# Altium schematic sheet CC_microcontroleur.SchDoc
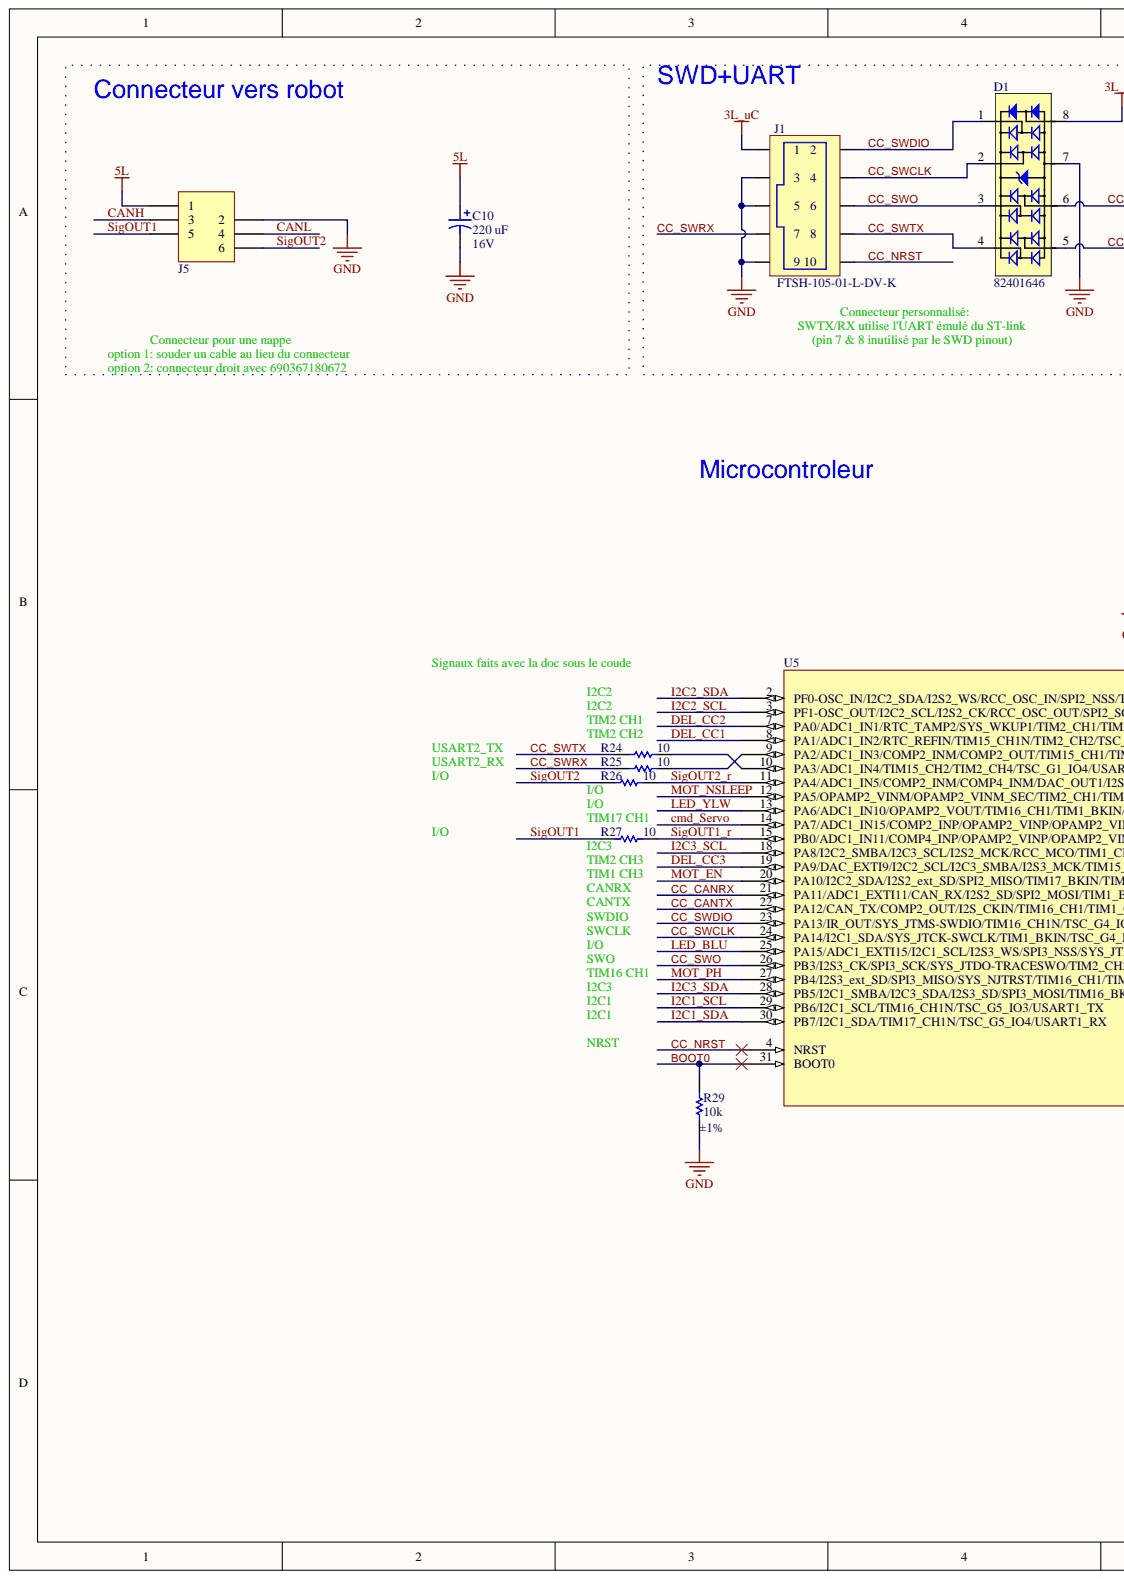
[diagram p0: CC_microcontroleur.SchDoc, left]
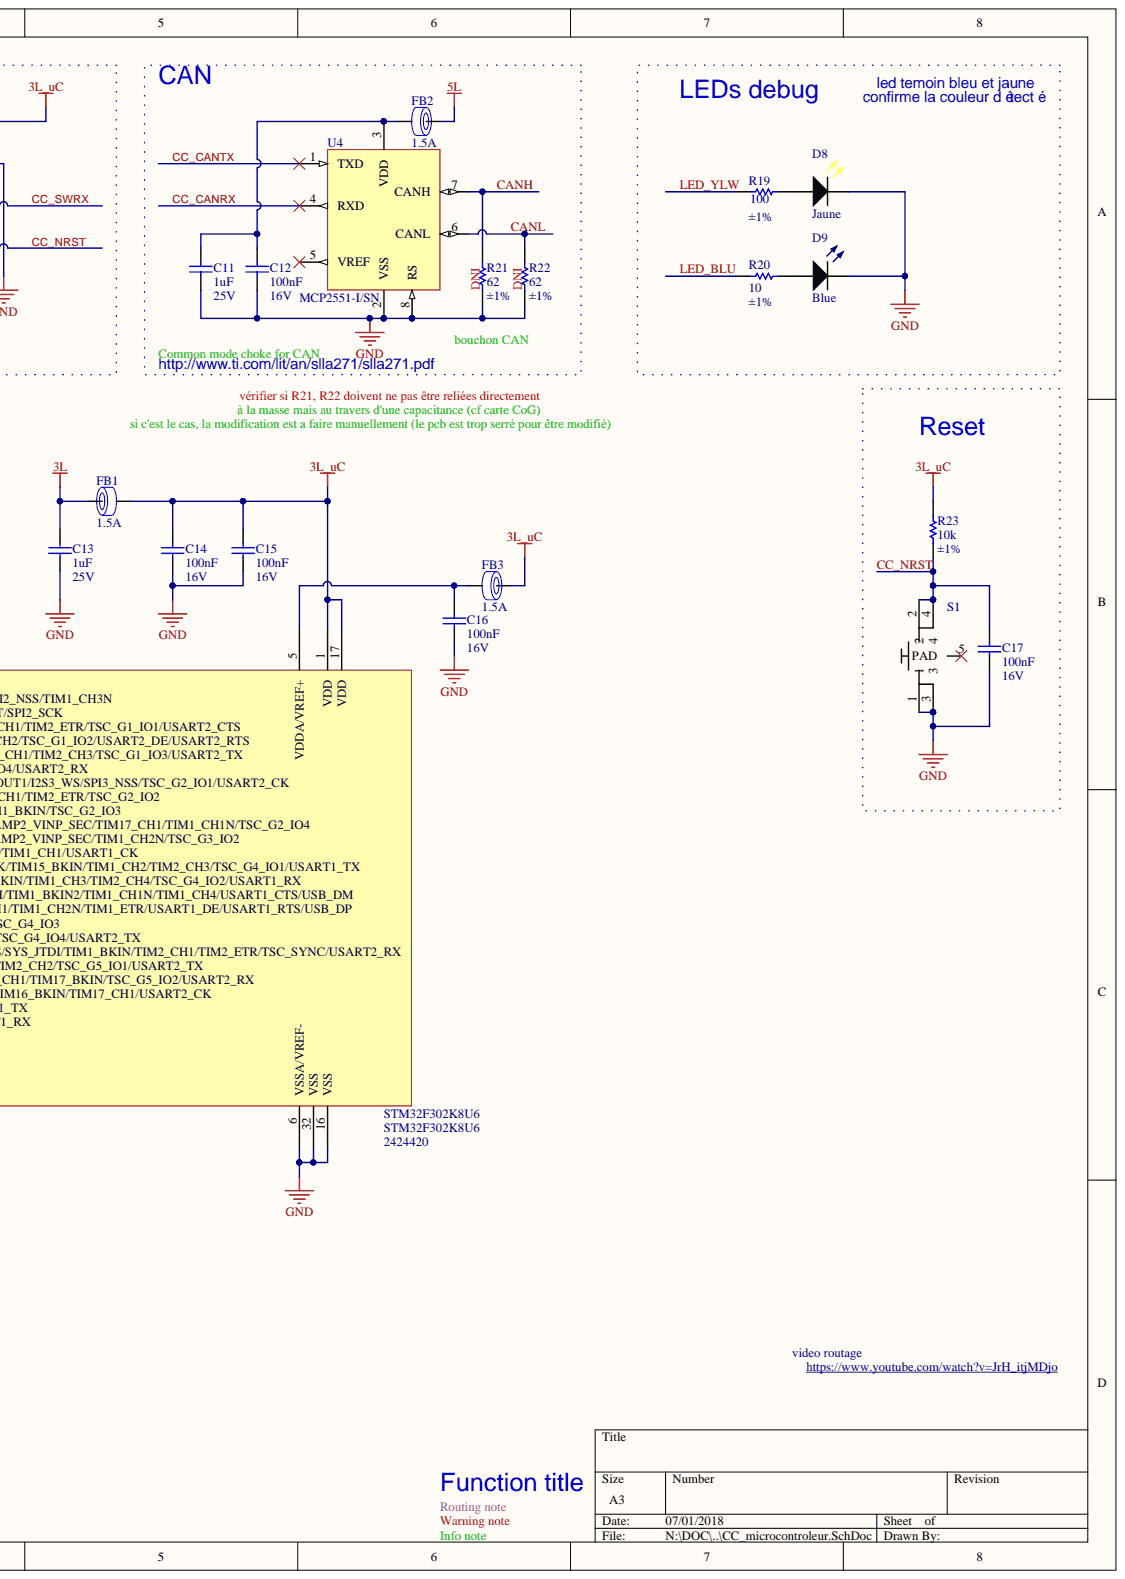
[diagram p1: CC_microcontroleur.SchDoc, right]

## Parts
C10  220 uF  RatedVoltage: 16V  [WCAP-ATG8_6.3x11x2.5x0.5]
C11  1uF  RatedVoltage: 25V  [WCAP-CSGP_0603, 1.6x0.8x0.8]
C12  100nF  RatedVoltage: 16V  [WCAP-CSGP_0402, 1x0.5x0.5]
C13  1uF  RatedVoltage: 25V  [WCAP-CSGP_0603, 1.6x0.8x0.8]
C14  100nF  RatedVoltage: 16V  [WCAP-CSGP_0402, 1x0.5x0.5]
C15  100nF  RatedVoltage: 16V  [WCAP-CSGP_0402, 1x0.5x0.5]
C16  100nF  RatedVoltage: 16V  [WCAP-CSGP_0402, 1x0.5x0.5]
C17  100nF  RatedVoltage: 16V  [WCAP-CSGP_0402, 1x0.5x0.5]
D1  82401646  [MSOP-8L]
D8  Jaune  [0603]
D9  Blue  [0603]
FB1  RatedCurrent: 1.5A  [WE-CBF_0603_High Current]  (hidden comment: 742792624)
FB2  RatedCurrent: 1.5A  [WE-CBF_0603_High Current]  (hidden comment: 742792624)
FB3  RatedCurrent: 1.5A  [WE-CBF_0603_High Current]  (hidden comment: 742792624)
J1  FTSH-105-01-L-DV-K  [FTSH-105-01-L-DV-K]
J5  value 6  [690368170672]  (hidden comment: 690368170672)
R19  value 100  Precision: ±1%  [RESC1005X04N]  (hidden comment: RC0402FR-07100RL)
R20  value 10  Precision: ±1%  [RESC1005X04N]  (hidden comment: RC0402FR-0710RL)
R21  value 62  Precision: ±1%  [RESC1005X04N]  (hidden comment: RC0402FR-0762RL)
R22  value 62  Precision: ±1%  [RESC1005X04N]  (hidden comment: RC0402FR-0762RL)
R23  value 10k  Precision: ±1%  [RESC1005X04N]  (hidden comment: RC0402FR-0710KL)
R24  value 10  [RESC1005X04N]  (hidden comment: RC0402FR-0710RL)
R25  value 10  [RESC1005X04N]  (hidden comment: RC0402FR-0710RL)
R26  value 10  [RESC1005X04N]  (hidden comment: RC0402FR-0710RL)
R27  value 10  [RESC1005X04N]  (hidden comment: RC0402FR-0710RL)
R29  value 10k  Precision: ±1%  [RESC1005X04N]  (hidden comment: RC0402FR-0710KL)
S1  MCITPG  [MCIPTG23K-V]  (value not drawn)
U4  MCP2551-I/SN  [SO8_N]
U5  STM32F302K8U6  Supplier Part Number 1: 2424420  [QFN50P500X500X60_HS-33N]

## Nets
3L: C13.2 FB1.1
3L_UC: C14.2 C15.2 D1.8 FB1.2 FB3.1 J1.1 R23.2 U5.1(VDD) U5.17(VDD)
5L: C10.1 FB2.1 J5.1
GND: C10.2 C11.1 C12.1 C13.1 C14.1 C15.1 C16.1 C17.1 D1.7 D8.1 D9.1 J1.3 J1.5 J1.9 J5.2 R21.1 R22.1 R29.1 S1.1 S1.3 U4.2(VSS) U4.8(RS) U5.6(VSSA/VREF-) U5.16(VSS) U5.32(VSS)
BOOT0: R29.2 U5.31(BOOT0)
CANH: J5.3 R21.2 U4.7(CANH)
CANL: J5.4 R22.2 U4.6(CANL)
CC_CANRX: U4.4(RXD) U5.21(PA11/ADC1_EXTI11/CAN_RX/I2S2_SD/SPI2_MOSI/TIM1_BKIN2/TIM1_CH1N/TIM1_CH4/USART1_CTS/USB_DM)
CC_CANTX: U4.1(TXD) U5.22(PA12/CAN_TX/COMP2_OUT/I2S_CKIN/TIM16_CH1/TIM1_CH2N/TIM1_ETR/USART1_DE/USART1_RTS/USB_DP)
CC_NRST: C17.2 D1.5 J1.10 R23.1 S1.2 S1.4 U5.4(NRST)
CC_SWCLK: D1.2 J1.4 U5.24(PA14/I2C1_SDA/SYS_JTCK-SWCLK/TIM1_BKIN/TSC_G4_IO4/USART2_TX)
CC_SWDIO: D1.1 J1.2 U5.23(PA13/IR_OUT/SYS_JTMS-SWDIO/TIM16_CH1N/TSC_G4_IO3)
CC_SWO: D1.3 J1.6 U5.26(PB3/I2S3_CK/SPI3_SCK/SYS_JTDO-TRACESWO/TIM2_CH2/TSC_G5_IO1/USART2_TX)
CC_SWRX: D1.6 J1.7 R25.1
CC_SWTX: D1.4 J1.8 R24.1
CMD_SERVO: U5.14(PA7/ADC1_IN15/COMP2_INP/OPAMP2_VINP/OPAMP2_VINP_SEC/TIM17_CH1/TIM1_CH1N/TSC_G2_IO4)
DEL_CC1: U5.8(PA1/ADC1_IN2/RTC_REFIN/TIM15_CH1N/TIM2_CH2/TSC_G1_IO2/USART2_DE/USART2_RTS)
DEL_CC2: U5.7(PA0/ADC1_IN1/RTC_TAMP2/SYS_WKUP1/TIM2_CH1/TIM2_ETR/TSC_G1_IO1/USART2_CTS)
DEL_CC3: U5.19(PA9/DAC_EXTI9/I2C2_SCL/I2C3_SMBA/I2S3_MCK/TIM15_BKIN/TIM1_CH2/TIM2_CH3/TSC_G4_IO1/USART1_TX)
I2C1_SCL: U5.29(PB6/I2C1_SCL/TIM16_CH1N/TSC_G5_IO3/USART1_TX)
I2C1_SDA: U5.30(PB7/I2C1_SDA/TIM17_CH1N/TSC_G5_IO4/USART1_RX)
I2C2_SCL: U5.3(PF1-OSC_OUT/I2C2_SCL/I2S2_CK/RCC_OSC_OUT/SPI2_SCK)
I2C2_SDA: U5.2(PF0-OSC_IN/I2C2_SDA/I2S2_WS/RCC_OSC_IN/SPI2_NSS/TIM1_CH3N)
I2C3_SCL: U5.18(PA8/I2C2_SMBA/I2C3_SCL/I2S2_MCK/RCC_MCO/TIM1_CH1/USART1_CK)
I2C3_SDA: U5.28(PB5/I2C1_SMBA/I2C3_SDA/I2S3_SD/SPI3_MOSI/TIM16_BKIN/TIM17_CH1/USART2_CK)
LED_BLU: R20.1 U5.25(PA15/ADC1_EXTI15/I2C1_SCL/I2S3_WS/SPI3_NSS/SYS_JTDI/TIM1_BKIN/TIM2_CH1/TIM2_ETR/TSC_SYNC/USART2_RX)
LED_YLW: R19.1 U5.13(PA6/ADC1_IN10/OPAMP2_VOUT/TIM16_CH1/TIM1_BKIN/TSC_G2_IO3)
MOT_EN: U5.20(PA10/I2C2_SDA/I2S2_ext_SD/SPI2_MISO/TIM17_BKIN/TIM1_CH3/TIM2_CH4/TSC_G4_IO2/USART1_RX)
MOT_NSLEEP: U5.12(PA5/OPAMP2_VINM/OPAMP2_VINM_SEC/TIM2_CH1/TIM2_ETR/TSC_G2_IO2)
MOT_PH: U5.27(PB4/I2S3_ext_SD/SPI3_MISO/SYS_NJTRST/TIM16_CH1/TIM17_BKIN/TSC_G5_IO2/USART2_RX)
SIGOUT1: J5.5 R27.1
SIGOUT1_R: R27.2 U5.15(PB0/ADC1_IN11/COMP4_INP/OPAMP2_VINP/OPAMP2_VINP_SEC/TIM1_CH2N/TSC_G3_IO2)
SIGOUT2: J5.6 R26.1
SIGOUT2_R: R26.2 U5.11(PA4/ADC1_IN5/COMP2_INM/COMP4_INM/DAC_OUT1/I2S3_WS/SPI3_NSS/TSC_G2_IO1/USART2_CK)
NetC11_2: C11.2 C12.2 FB2.2 U4.3(VDD)
NetC16_2: C16.2 FB3.2 U5.5(VDDA/VREF+)
NetD8_2: D8.2 R19.2
NetD9_2: D9.2 R20.2
NetR24_2: R24.2 U5.10(PA3/ADC1_IN4/TIM15_CH2/TIM2_CH4/TSC_G1_IO4/USART2_RX)
NetR25_2: R25.2 U5.9(PA2/ADC1_IN3/COMP2_INM/COMP2_OUT/TIM15_CH1/TIM2_CH3/TSC_G1_IO3/USART2_TX)

Not connected: S1 5(PAD); U4 5(VREF)

## Library data of the parts
C10 = WCAP-ATG8, 860010373010: CAP Alu 220 UF 20% 16 V; Manufacturer: Wurth Elektronik; Component Kind: Standard; ComponentLink1Description: Manufacturer; ComponentLink1URL: http://www.we-online.de; ComponentLink2Description: Datasheet; ComponentLink2URL: http://katalog.we-online.de/pbs/datasheet/860010373010.pdf; Manufacturer Part Number: 860010373010; PublishedDate: July 2016; Size: 6.3mmx11mm; Value: 220 uF; Library Name: Condensateur.IntLib
C11 C13 = WCAP-CSGP, 885012206076: CAP CER 1uF 25V X7R 0603; Manufacturer: Wurth Elektronik; CeramicType: X7R; Component Kind: Standard; ComponentLink1Description: Manufacturer; ComponentLink1URL: http://www.we-online.de; ComponentLink2Description: Datasheet; ComponentLink2URL: http://katalog.we-online.com/pbs/datasheet/885012206076.pdf; Manufacturer Part Number: 885012206076; PublishedDate: Aug 2016; Size: 0603; Value: 1uF; Library Name: Condensateur.IntLib
C12 C14-C17 = WCAP-CSGP, 885012205037: CAP CER 100nF 16V X7R 0402; Manufacturer: Wurth Elektronik; CeramicType: X7R; Component Kind: Standard; ComponentLink1Description: Manufacturer; ComponentLink1URL: http://www.we-online.de; ComponentLink2Description: Datasheet; ComponentLink2URL: http://katalog.we-online.com/pbs/datasheet/885012205037.pdf; Manufacturer Part Number: 885012205037; PublishedDate: Aug 2016; Size: 0402; Value: 100nF; Library Name: Condensateur.IntLib
D1 = 82401646: TVS DIODE 5VWM 13VC 8MSOP; Manufacturer: Wurth Electronics Inc.; Component Kind: Standard; ComponentLink1Description: Datasheet; ComponentLink1URL: http://katalog.we-online.de/pbs/datasheet/82401646.pdf; Manufacturer Part Number: 82401646; Supplier 1: Farnell; Supplier Part Number 1: 1825864; Library Name: Redressement.SchLib
D8 = WL-SMCW 0603  150060YS75000: SMD mono-color Chip LED, WL-SMCW, Yellow; Manufacturer 1: Würth Elektronik eiSos GmbH; Color: Yellow; Component Kind: Standard; ComponentLink1Description: Manufacturer; ComponentLink1URL: http://www.we-online.de; ComponentLink2Description: Datasheet; ComponentLink2URL: http://katalog.we-online.com/led/datasheet/150060YS75000.pdf; Created Date: June 2013; Manufacturer Part Number: 150060YS75000; Supplier 1: Farnell; Supplier Part Number 1: 2322074; Value: Jaune; Created by: Christin Otto; Library Name: Optoélectronique.IntLib
D9 = WL-SMCW 0603  150060BS75000: SMD mono-color Chip LED, WL-SMCW, Blue; Manufacturer 1: Würth Elektronik eiSos GmbH; Color: Blue; Component Kind: Standard; ComponentLink1Description: Manufacturer; ComponentLink1URL: http://www.we-online.de; ComponentLink2Description: Datasheet; ComponentLink2URL: http://katalog.we-online.com/led/datasheet/150060BS75000.pdf; Created Date: June 2013; Manufacturer Part Number: 150060BS75000; Supplier 1: Farnell; Supplier Part Number 1: 2322069; Value: Blue; Created by: Christin Otto; Library Name: Optoélectronique.IntLib
FB1-FB3 = WE-CBF, 742792624: Ferrite Bead 180ohm 0603 max1.5A; Manufacturer: Wurth Elektronik; Type: High Current; Value: 180Ω; Component Kind: Standard; ComponentLink1Description: Manufacturer; ComponentLink1URL: http://www.we-online.de; ComponentLink2Description: Datasheet; ComponentLink2URL: http://katalog.we-online.de/pbs/datasheet/742792624.pdf; Library Name: Inductance.IntLib; Manufacturer Part Number: 742792624; PublishedDate: Aug 2016; Size: 0603
J1 = FTSH-105-01-L-DV-K: CONN HEADER 10 POS DUAL .05" SMD with Keying; Manufacturer: Samtec Inc.; Component Kind: Standard; ComponentLink1Description: Datasheet; ComponentLink1URL: http://suddendocs.samtec.com/prints/ftsh-1xx-xx-xxx-dv-xxx-xxx-mkt.pdf; Manufacturer Part Number: FTSH-105-01-L-DV-K; Supplier 1: Digi-Key; Supplier Part Number 1: SAM8799-ND; Library Name: Connecteurs.IntLib
J5 = 690368170672: WR-MM 6p Female Angled TH Connector with Polarization; Manufacturer: Wurth Electronics Inc.; Component Kind: Standard; ComponentLink1Description: Manufacturer; ComponentLink1URL: http://www.we-online.com; ComponentLink2Description: Datasheet; ComponentLink2URL: http://katalog.we-online.de/em/datasheet/69035710xx72.pdf; Manufacturer Part Number: 690368170672; Supplier 1: Farnell; Supplier Part Number 1: 1641862; Library Name: Connecteurs.IntLib
R19 = RC0402FR-07100RL: RES SMD 100 OHM 1% 1/16W 0402; Manufacturer: Yageo; Power: 63mW; Vmax: 50V; Component Kind: Standard; ComponentLink1Description: Manufacturer; ComponentLink1URL: http://www.yageo.com/NewPortal/_en/index.jsp; ComponentLink2Description: Datasheet; ComponentLink2URL: http://www.farnell.com/datasheets/2167052.pdf; Manufacturer Part Number: RC0402FR-07100RL; Supplier 1: Farnell; Supplier Part Number 1: 9239111; Type: Thick Film; Library Name: Resistance.IntLib
R20 R24-R27 = RC0402FR-0710RL: RES SMD 10 OHM 1% 1/16W 0402; Manufacturer: Yageo; Power: 63mW; Vmax: 50V; Component Kind: Standard; ComponentLink1Description: Manufacturer; ComponentLink1URL: http://www.yageo.com/NewPortal/_en/index.jsp; ComponentLink2Description: Datasheet; ComponentLink2URL: http://www.farnell.com/datasheets/1018594.pdf; Manufacturer Part Number: RC0402FR-0710RL; Supplier 1: Farnell; Supplier Part Number 1: 9238999; Type: Thick Film; Library Name: Resistance.IntLib
  R24-R27: Precision: ±1%
R21 R22 = RC0402FR-0762RL: RES SMD 62 OHM 1% 1/16W 0402; Manufacturer: Yageo; Power: 63mW; Vmax: 50V; Component Kind: Standard; ComponentLink1Description: Manufacturer; ComponentLink1URL: http://www.yageo.com/NewPortal/_en/index.jsp; ComponentLink2Description: Datasheet; ComponentLink2URL: http://www.farnell.com/datasheets/2167052.pdf; Manufacturer Part Number: RC0402FR-0762RL; Supplier 1: Farnell; Supplier Part Number 1: 1458813; Type: Thick Film; Library Name: Resistance.IntLib
R23 R29 = RC0402FR-0710KL: RES SMD 10K OHM 1% 1/16W 0402; Manufacturer: Yageo; Power: 63mW; Vmax: 50V; Component Kind: Standard; ComponentLink1Description: Manufacturer; ComponentLink1URL: http://www.yageo.com/NewPortal/_en/index.jsp; ComponentLink2Description: Datasheet; ComponentLink2URL: http://www.farnell.com/datasheets/2167052.pdf; Manufacturer Part Number: RC0402FR-0710KL; Supplier 1: Farnell; Supplier Part Number 1: 9239359; Type: Thick Film; Library Name: Resistance.IntLib
S1 = MCIPTG23K-V: Switch MCIPTG23K-V Multicomp; Component Kind: Standard; ComponentLink1Description: http://www.farnell.com/cad/1662547.pdf; ComponentLink1URL: http://www.farnell.com/cad/1662547.pdf; Manufacturer: MULTICOMP; Manufacturer Part Number: MCIPTG23K-V; Pricing: 1=0.7, 50=0.65, 100=0.61, 500=0.57, 1000=0.53, 7000=0.37, 7000=0.333, 30000=0.3 (EUR); Supplier 1: Farnell; Supplier Part Number 1: 1605470; Library Name: Switchs.IntLib
U4 = MCP2551-I/SN: IC TRANSCEIVER CAN HI-SPD 8-SOIC; Manufacturer: Microchip Technology; Component Kind: Standard; ComponentLink1Description: Datasheet; ComponentLink1URL: http://www.farnell.com/datasheets/657417.pdf; Library Name: Serial.IntLib; Manufacturer Part Number: MCP2551-I/SN; Supplier 1: Farnell; Supplier Part Number 1: 9758569
U5 = STM32F302K8U6: Manufacturer: STMICROELECTRONICS; Component Kind: Standard; Description: STM32F302K8U6; Library Name: Stm32F3.SchLib; Manufacturer Part Number: STM32F302K8U6; Pricing: 1=0.5, 25=0.4, 100=0.33, 250=0.27, 500=0.228, 2000=0.16, 2000=0.157, 20000=0.154 (EUR); Supplier 1: Farnell
